# Altium schematic sheet 03-Power.SchDoc(03-Power)
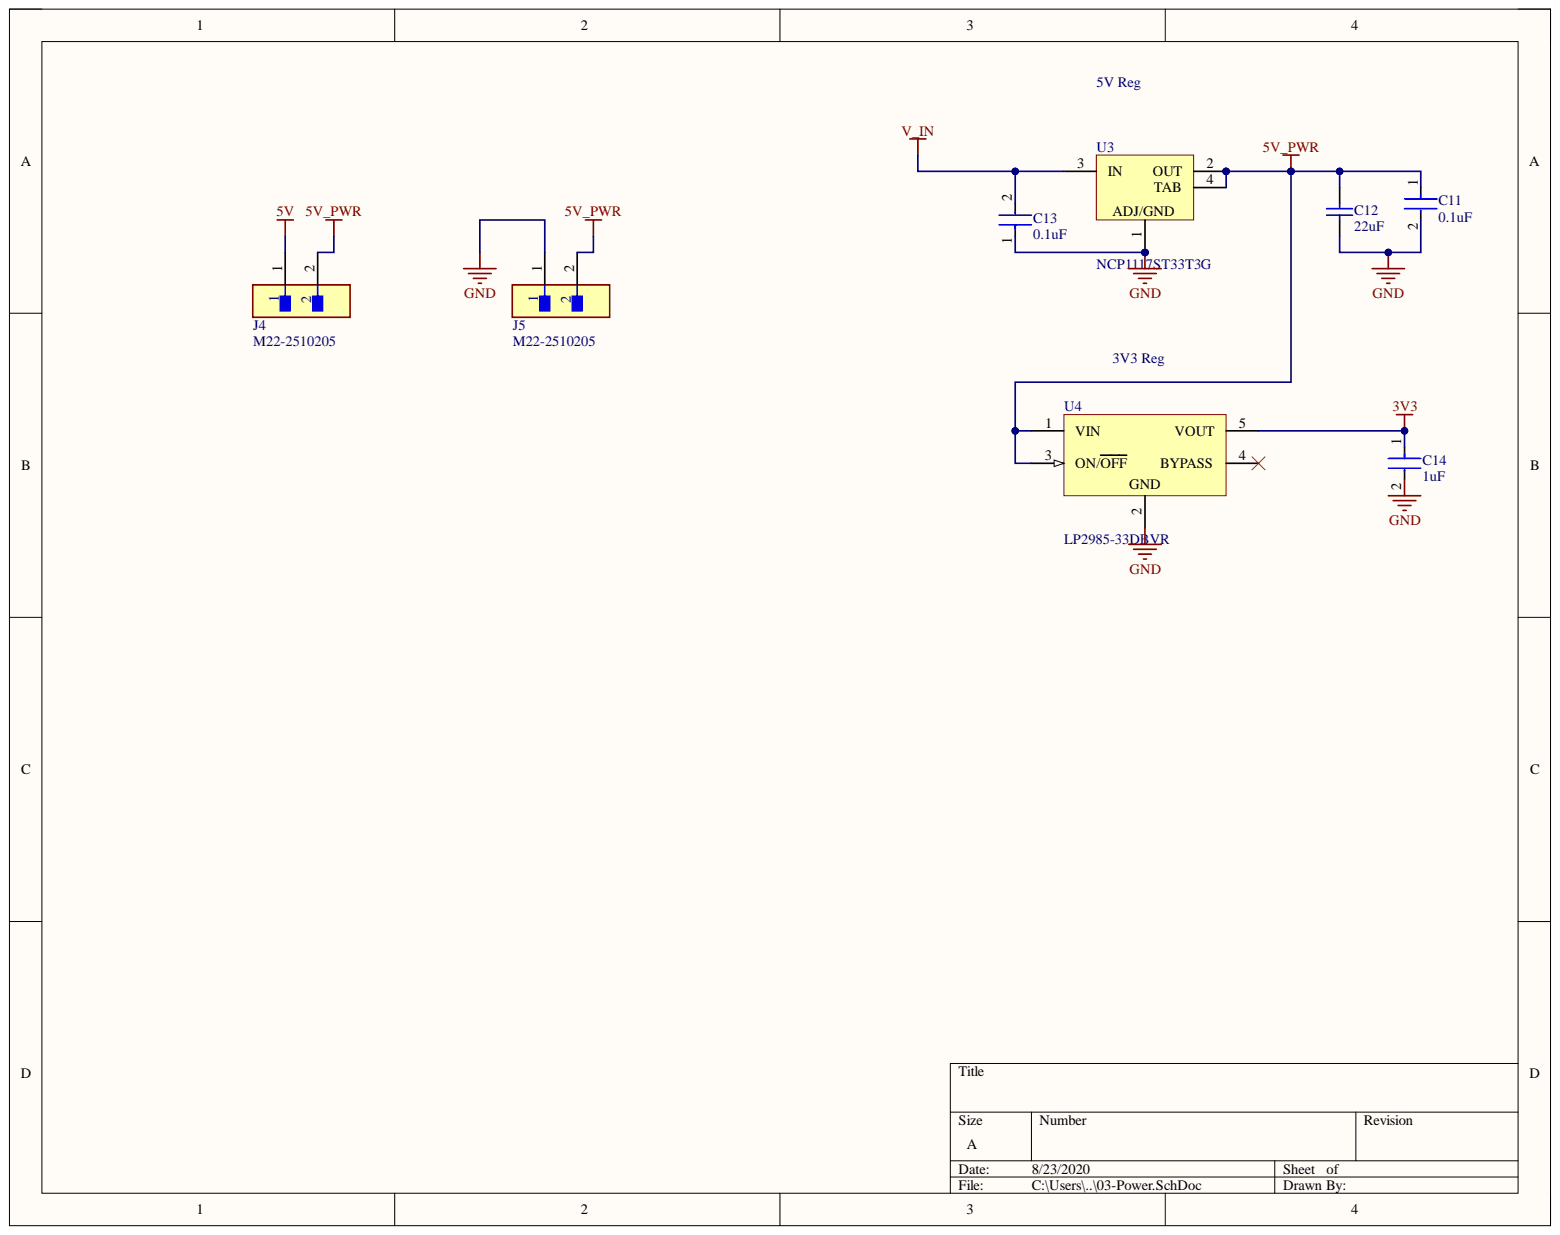
[diagram p0: 03-Power.SchDoc]

## Parts
C11  0.1uF  [FP-C1206C-EB-MFG]
C12  22uF  [CAPC2013X140X45NL15T25]
C13  0.1uF  [FP-C1206C-EB-MFG]
C14  1uF  [FP-GRM15-0_1-MFG]
J4  M22-2510205  [FP-M22-2510205-MFG]
J5  M22-2510205  [FP-M22-2510205-MFG]
U3  NCP1117ST33T3G  [ONSC-SOT-223-4-318H-01_A_V]
U4  LP2985-33DBVR  [DBV0005A_M]

## Nets
3V3: C14.1 U4.5(VOUT)
5V: J4.1
5V_PWR: C11.1 C12.2 J4.2 J5.2 U3.2(OUT) U3.4(TAB) U4.1(VIN) U4.3(ON/OFF)
GND: C11.2 C12.1 C13.1 C14.2 J5.1 U3.1 U4.2
V_IN: C13.2 U3.3(IN)

Not connected: U4 4(BYPASS)

## Library data of the parts
C11 C13 = CMP-03020-000153-1: CAP CER 0.1UF 100V X7R 1206; Manufacturer: KEMET; Manufacturer Part Number: C1206C104K1RACTU; Automotive Grade: Grade 0; Capacitance: 0.10 μF; Material: Ceramic; Temperature Coefficient: 15%; Tolerance: 0.1; Value: 0.10 μF; Automotive: Yes; Category: Cap; Component Kind: Standard; ComponentLink2Description: Datasheet; ComponentLink2URL: https://content.kemet.com/datasheets/KEM_C1007_X8R_ULTRA_150C_SMD.pdf; Device Class L1: Passive Components; Device Class L2: Capacitors; Device Class L3: Ceramic Capacitors; Digikey Description: CAP CER 0.1UF 100V X7R 1206; Height: 0.88mm; Ipc Land Pattern Name: CAPC320160X78; Lead Free: Yes; Library Name: Altium Content Vault; Mouser Description: CAP CER 0.1UF 100V X7R 1206; Octopart: https://octopart.com/c1206c104k1ractu-kemet-89521; Package: C1206C-EB; RoHS: TRUE; Temperature: -55°C to +150°C; Temperature Characteristic: X7R; Temperature Range High: +150°C; Temperature Range Low: -55°C; Voltage Rating: 100 V
C12 = CMP-2007-03385-1: Manufacturer: Murata; Part Number: GRM21BR60J226ME39L; Component Kind: Standard; Library Name: Altium Content Vault
C14 = CMP-06035-000073-1: CAP CER 1UF 10V X5R 0402; Manufacturer: Murata; Manufacturer Part Number: GRM155R61A105KE15D; Material: Ceramic; Temperature Coefficient: 15%; Tolerance: 10%; Automotive: No; Capacitance: 1uF; Category: Cap; Component Kind: Standard; ComponentLink2Description: Datasheet; ComponentLink2URL: https://search.murata.co.jp/Ceramy/image/img/A01X/G101/ENG/GRM155R61A105KE15-01A.pdf; Device Class L1: Passive Components; Device Class L2: Capacitors; Device Class L3: Ceramic Capacitors; Digikey Description: CAP CER 1UF 10V X5R 0402; Height: 0.55mm; Ipc Land Pattern Name: CAPC10050X50; Lead Free: Yes; Library Name: Altium Content Vault; Mouser Description: 0402 1 uF 10 V ±10% Tolerance X5R Surface Mount Multilayer Ceramic Capacitor; Octopart: https://octopart.com/grm155r61a105ke15d-murata-197442; Package: GRM15-0.1; RoHS: TRUE; Temperature: -55°C to +85°C; Temperature Characteristic: X5R; Temperature Range High: +85°C; Temperature Range Low: -55°C; Value: 1uF; Voltage Rating: 10V
J4 J5 = CMP-15831-000005-1: CONN HEADER VERT 2POS 2MM; Manufacturer: Harwin; Conn Gender: Male; Conn Orientation: Vertical; Current Rating: 2A; Number Of Contacts: 2; Automotive: No; Category: Conn; Component Kind: Standard; ComponentLink2Description: Datasheet; ComponentLink2URL: https://cdn.harwin.com/pdfs/C018XX_M22_Series_Connectors.pdf; Contact Material: Gold,Nickel; Device Class L1: Connectors; Device Class L2: Headers and Wire Housings; Device Class L3: unset; Digikey Description: CONN HEADER VERT 2POS 2MM; Footprint Url: https://cdn.harwin.com/pdfs/M22-251.pdf; Height: 5.45mm; Lead Free: Yes; Library Name: Altium Content Vault; Manufacturer Part Number: M22-2510205; Mouser Description: Headers & Wire Housings 2 PIN SIL VERTICAL GOLD PIN HEADER; Number Of Rows: 1; Octopart: https://octopart.com/m22-2510205-harwin-2538221; Package: 0; Pitch: 2mm; RoHS: TRUE; Temperature: -40°C to +105°C; Temperature Range High: +105°C; Temperature Range Low: -40°C
U3 = CMP-1679-00010-1: Low-Dropout Positive Fixed Voltage Regulator, 1 A, 3.3 V Output Voltage, 0 to 125 degC, 4-Pin SOT-223 (318H-01), Pb-Free, Tape and Reel; Case\Package: 0603; Power Rating: 100 mW; Resistance: 220 kohm; Tolerance: 1%; Type (Resistor): Thick Film; Voltage Rating: 75 V; Case Code (Imperial): 0603; Case Code (Metric): 1608; Component Kind: Standard; ComponentLink1Description: Manufacturer URL; ComponentLink1URL: http://www.onsemi.com/; ComponentLink2Description: Datasheet; ComponentLink2URL: http://www.onsemi.com/pub_link/Collateral/NCP1117-D.PDF; DatasheetVersion: Rev. 27, 09/2014; Height: 0.45 mm; Length: 1.55 mm; Library Name: Altium Content Vault; Max Operating Temperature: 155 degC; Min Operating Temperature: -55 degC; Mount: Surface Mount; Package Quantity: 5000; PackageDescription: 4-Pin Small Outline Transistor, Body 6.5 x 3.5 mm, Pitch 2.3 mm; PackageReference: SOT-223-4-318H-01_A; Packaging: Tape and Reel; Radiation Hardening: No; REACH SVHC: Unknown; RoHSCompliant: true; Weight: 7.1E-05 oz; Width: 0.85 mm
U4 = CMP-0758-00080-2: Single Output Low Noise LDO, 150 mA, Fixed 3.3 V Output, 1.5% Tolerance, 2.2 to 16 V Input, Low IQ, 5-Pin SOT-23 (DBV), -40 to 125 degC, Green (RoHS & no Sb/Br), Tape and Reel; Manufacturer: Texas Instruments; Accuracy (%): 1.5; Fixed Output Options (V): 3.3; Iout (Max) (A): 0.15; Iq (Typ) (mA): 0.85; Output Options: Fixed Output; Vdo (Typ) (mV): 280; Component Kind: Standard; ComponentLink1Description: Manufacturer URL; ComponentLink1URL: http://www.ti.com/; ComponentLink2Description: Datasheet; ComponentLink2URL: http://www.ti.com/lit/ds/symlink/lp2985-50.pdf; ComponentLink3Description: Package Specification; ComponentLink3URL: http://www.ti.com/litv/pdf/mpds018j; DatasheetVersion: SLVS522N; Library Name: Altium Content Vault; Mounting Technology: Surface Mount; Operating Temperature Range (degC): -40 to 125; PackageDescription: 5-Pin Plastic Small Outline, Body 2.9 x 1.6 mm, Pitch 0.95 mm; PackageReference: DBV0005A; PackageVersion: 4073253-4/L; Packing: Tape and Reel; PartNumber: LP2985-33DBVR; RoHS: TRUE; Vin (V): 2.2 to 16
